# Altium schematic sheet Connectors.SchDoc
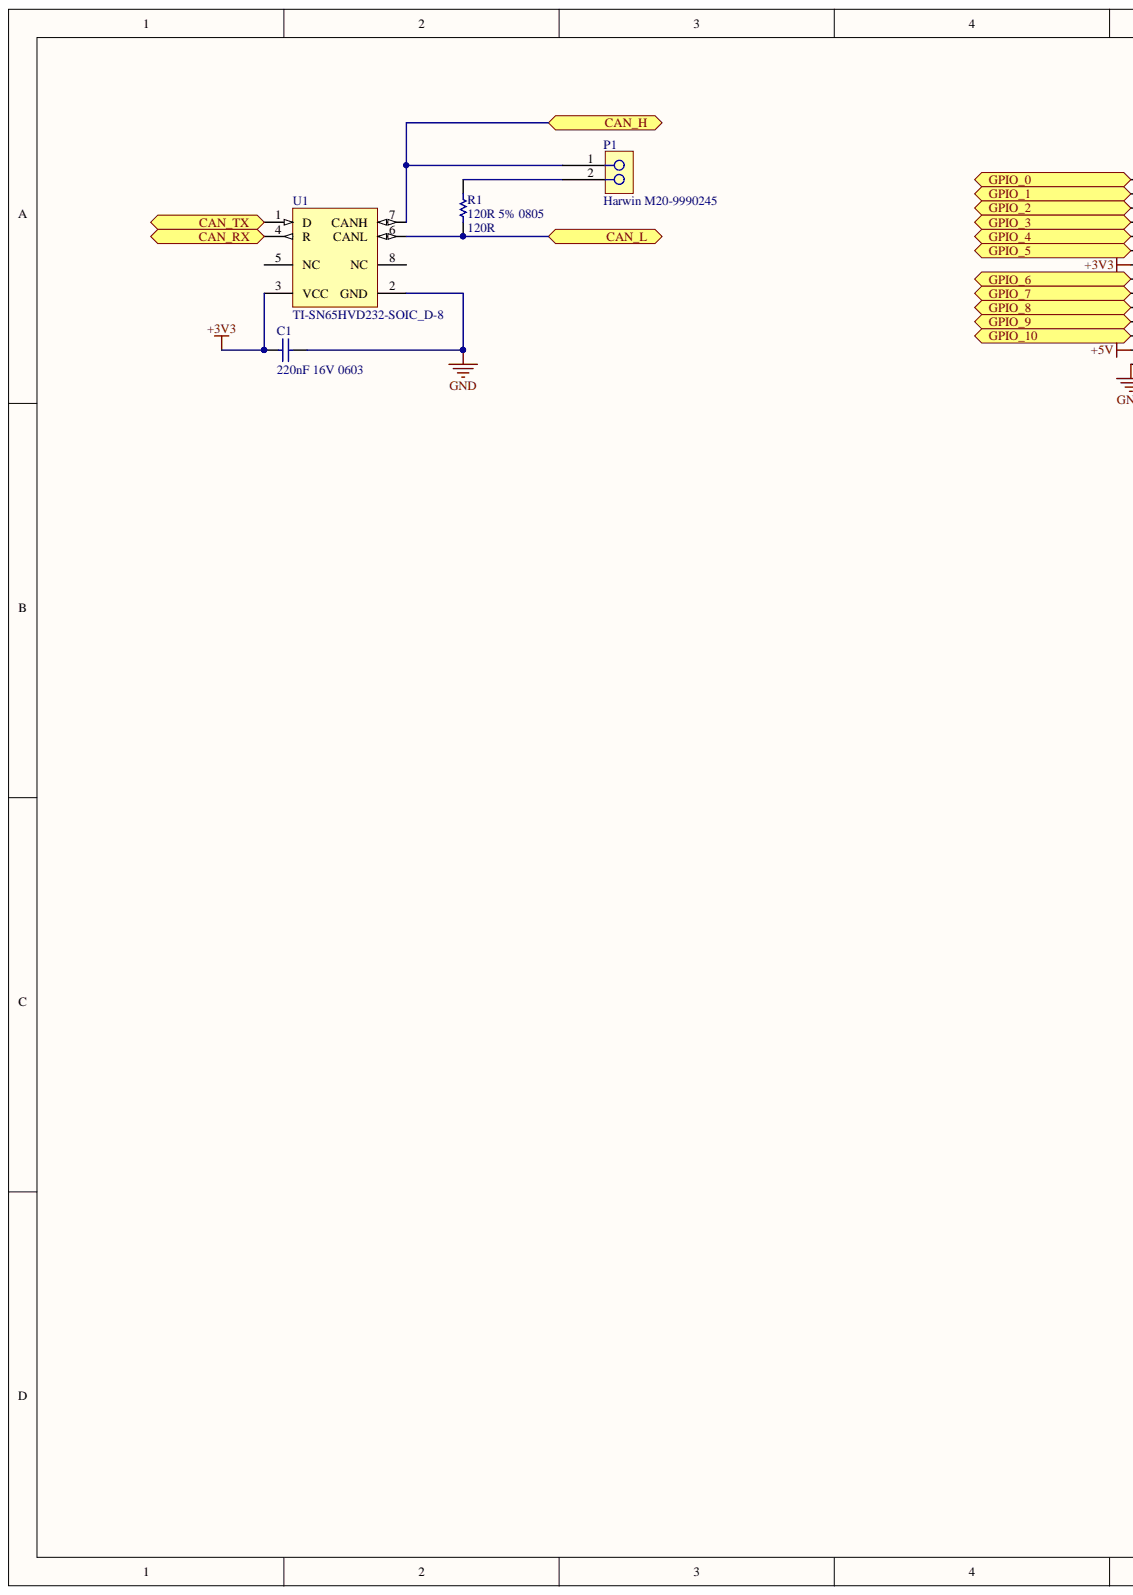
[diagram p0: Connectors.SchDoc, left]
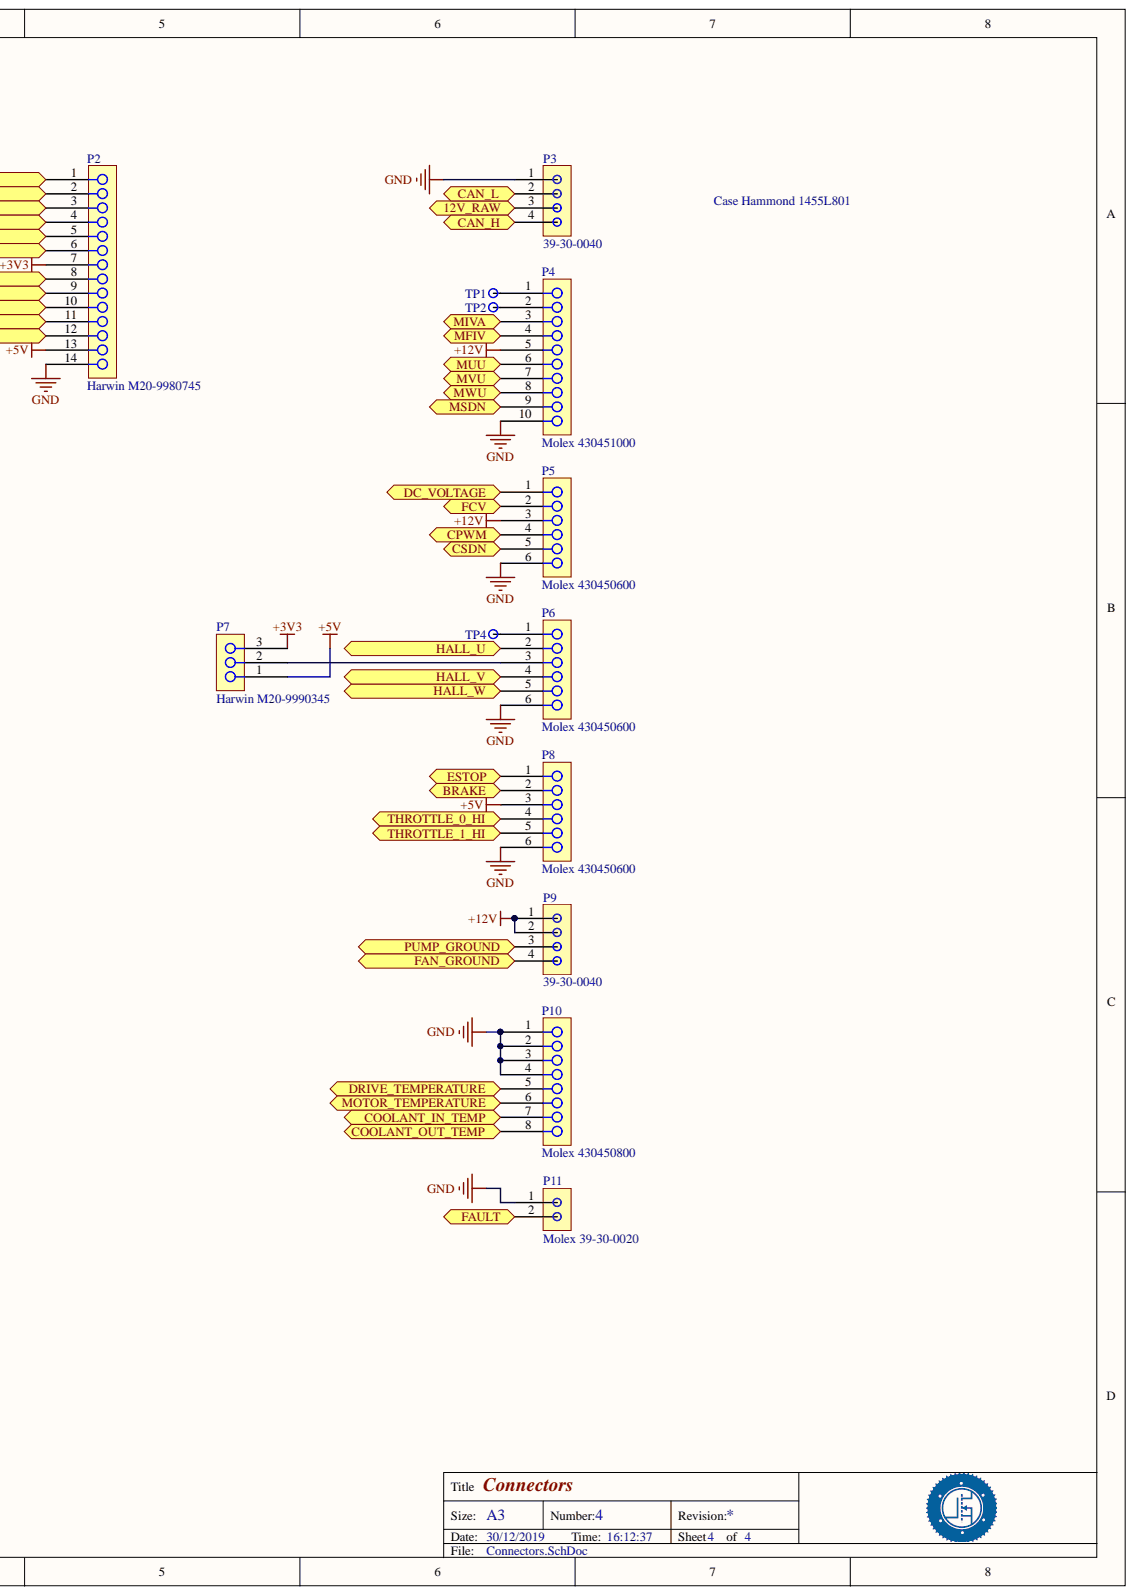
[diagram p1: Connectors.SchDoc, right]

## Parts
C1  220nF 16V 0603  value 220nF  Case-EIA: 0603  [CAPC0603(1608)100_N]
P1  Harwin M20-9990245  Manufacturer: Harwin  PartNumber: M20-9990245  [HEADER_2]
P2  Harwin M20-9980745  Manufacturer: Harwin  PartNumber: M20-9980745  [Header_7x2]
P3  39-30-0040  [Molex_39-30-0040]
P4  Molex 430451000  Manufacturer: Molex  PartNumber: 430451000  [Molex_430451000]
P5  Molex 430450600  Manufacturer: Molex  PartNumber: 430450600  [Molex_430450600]
P6  Molex 430450600  Manufacturer: Molex  PartNumber: 430450600  [Molex_430450600]
P7  Harwin M20-9990345  Manufacturer: Harwin  PartNumber: M20-9990345  [HEADER_3]
P8  Molex 430450600  Manufacturer: Molex  PartNumber: 430450600  [Molex_430450600]
P9  39-30-0040  [Molex_39-30-0040]
P10  Molex 430450800  Manufacturer: Molex  ManufacturerPartNumber: 430450800  [Molex_430450800]
P11  Molex 39-30-0020  value 39-30-0020  Manufacturer: Molex  [Molex_39-30-0020]
R1  120R 5% 0805  value 120R  [RESC0805(2012)_N]
TP1  TP1MM  [TP1MM]  (value not drawn)
TP2  TP1MM  [TP1MM]  (value not drawn)
TP4  TP1MM  [TP1MM]  (value not drawn)
U1  TI-SN65HVD232-SOIC_D-8  [D0008A_N]

## Ports
12V_RAW, BRAKE, CAN_H, CAN_L, CAN_RX, CAN_TX, COOLANT_IN_TEMP, COOLANT_OUT_TEMP, CPWM, CSDN, DC_VOLTAGE, DRIVE_TEMPERATURE, ESTOP, FAN_GROUND, FAULT, FCV, GPIO_0, GPIO_1, GPIO_2, GPIO_3, GPIO_4, GPIO_5, GPIO_6, GPIO_7, GPIO_8, GPIO_9, GPIO_10, HALL_U, HALL_V, HALL_W, MFIV, MIVA, MOTOR_TEMPERATURE, MSDN, MUU, MVU, MWU, PUMP_GROUND, THROTTLE_0_HI, THROTTLE_1_HI

## Nets
+3V3: C1.2 P2.7 P7.3 U1.3(VCC)
+5V: P2.13 P7.1 P8.3
+12V: P4.5 P5.3 P9.1 P9.2
GND: C1.1 P2.14 P3.1 P4.10 P5.6 P6.6 P8.6 P10.1 P10.2 P10.3 P10.4 P11.1 U1.2(GND)
12V_RAW: P3.3 ; port 12V_RAW
BRAKE: P8.2 ; port BRAKE
CAN_H: P1.1 P3.4 U1.7(CANH) ; port CAN_H
CAN_L: P3.2 R1.1 U1.6(CANL) ; port CAN_L
CAN_RX: U1.4(R) ; port CAN_RX
CAN_TX: U1.1(D) ; port CAN_TX
COOLANT_IN_TEMP: P10.7 ; port COOLANT_IN_TEMP
COOLANT_OUT_TEMP: P10.8 ; port COOLANT_OUT_TEMP
CPWM: P5.4 ; port CPWM
CSDN: P5.5 ; port CSDN
DC_VOLTAGE: P5.1 ; port DC_VOLTAGE
DRIVE_TEMPERATURE: P10.5 ; port DRIVE_TEMPERATURE
ESTOP: P8.1 ; port ESTOP
FAN_GROUND: P9.4 ; port FAN_GROUND
FAULT: P11.2 ; port FAULT
FCV: P5.2 ; port FCV
GPIO_0: P2.1 ; port GPIO_0
GPIO_1: P2.2 ; port GPIO_1
GPIO_2: P2.3 ; port GPIO_2
GPIO_3: P2.4 ; port GPIO_3
GPIO_4: P2.5 ; port GPIO_4
GPIO_5: P2.6 ; port GPIO_5
GPIO_6: P2.8 ; port GPIO_6
GPIO_7: P2.9 ; port GPIO_7
GPIO_8: P2.10 ; port GPIO_8
GPIO_9: P2.11 ; port GPIO_9
GPIO_10: P2.12 ; port GPIO_10
HALL_U: P6.2 ; port HALL_U
HALL_V: P6.4 ; port HALL_V
HALL_W: P6.5 ; port HALL_W
MFIV: P4.4 ; port MFIV
MIVA: P4.3 ; port MIVA
MOTOR_TEMPERATURE: P10.6 ; port MOTOR_TEMPERATURE
MSDN: P4.9 ; port MSDN
MUU: P4.6 ; port MUU
MVU: P4.7 ; port MVU
MWU: P4.8 ; port MWU
PUMP_GROUND: P9.3 ; port PUMP_GROUND
THROTTLE_0_HI: P8.4 ; port THROTTLE_0_HI
THROTTLE_1_HI: P8.5 ; port THROTTLE_1_HI
NetP1_2: P1.2 R1.2
NetP4_1: P4.1 TP1.1
NetP4_2: P4.2 TP2.1
NetP6_1: P6.1 TP4.1
NetP6_3: P6.3 P7.2

Not connected: U1 5(NC) 8(NC)

## Library data of the parts
C1 = CMP-1035-02644-1: CAP 220nF 16V ±10% 0603 (1608 Metric) Thickness 1mm SMD; Case-Metric: 1608; Tolerance: Â±10%; Component Kind: Standard; Library Name: Altium Content Vault; Max Thickness: 1 mm; Rated Voltage: 16 V; Technology: SMT
P1 = Header_2: Connector Header Through Hole 2 position; Component Kind: Standard; Library Name: sl-altium.SchLib
P2 = Header_7x2: Connector Header Through Hole 7x2 position; Component Kind: Standard; Library Name: sl-altium.SchLib
P3 P9 = 39-30-0040: Mini-Fit Jr. Header, 4 Circuits, Dual Row, Right-Angle, with Snap-in Plastic Peg PCB Lock, PA Polyamide Nylon 6/6 94V-0, 2.54µm Matte Tin (Sn) Plating; Manufacturer: Molex; Component Kind: Standard; Library Name: sl-altium.SchLib; Value: 39-30-0040
P4 = Molex_430451000: Micro-Fit 3.0 Right-Angle Header, Dual Row, 10 Circuits, with Snap-in Plastic Peg PCB Lock, Tin; Component Kind: Standard; Library Name: sl-altium.SchLib
P5 P6 P8 = Molex_430450600: Micro-Fit 3.0 Right-Angle Header, 3.00mm Pitch, Dual Row, 6 Circuits, with Snap-in Plastic Peg PCB Lock, Tin, Glow-Wire Capable, Black; Component Kind: Standard; Library Name: sl-altium.SchLib
P7 = Header_3: Connector Header Through Hole 3 position; Component Kind: Standard; Library Name: sl-altium.SchLib
P10 = Molex_430450800: Micro-Fit 3.0 Right-Angle Header, 3.00mm Pitch, Dual Row, 8 Circuits, with Snap-in Plastic Peg PCB Lock, Tin, Glow-Wire Capable, Black; Component Kind: Standard; Library Name: sl-altium.SchLib
P11 = 39-30-0020: Mini-Fit Jr. Header, 2 Circuits, Dual Row, Right-Angle, with Snap-in Plastic Peg PCB Lock, PA Polyamide Nylon 6/6 94V-0, 2.54µm Matte Tin (Sn) Plating; Component Kind: Standard; Library Name: sl-altium.SchLib
R1 = CMP-1013-00052-1: 120R 0.125W 5% 0805 (2012 Metric)  SMD; Case-EIA: 0805; Case-Metric: 2012; Component Kind: Standard; Library Name: Altium Content Vault; Power: 0.125 W; Technology: SMT; Tolerance: 5 %
TP1 TP2 TP4 = TP1MM: 1mm test pad; Component Kind: Standard; Library Name: sl-altium.SchLib
U1 = CMP-0315-00075-2: 3.3 V Can Transceiver, 17 mA, -40 to 85 degC, 8-pin SOIC (D), Green (RoHS & no Sb/Br); Manufacturer: Texas Instruments; PartNumber: SN65HVD232DR; ICC(Max)(mA): 17; Receivers Per Package: 1; Supply Voltage(s)(V): 3.3; Code_JEDEC: MS-012AA; Component Kind: Standard; Eco-Plan: Green (RoHS & no Sb/Br); Isolated: No; Library Name: Altium Content Vault; Mounting Technology: Surface Mount; SubFamily: CAN
